# Altium schematic sheet AD7606C.SchDoc
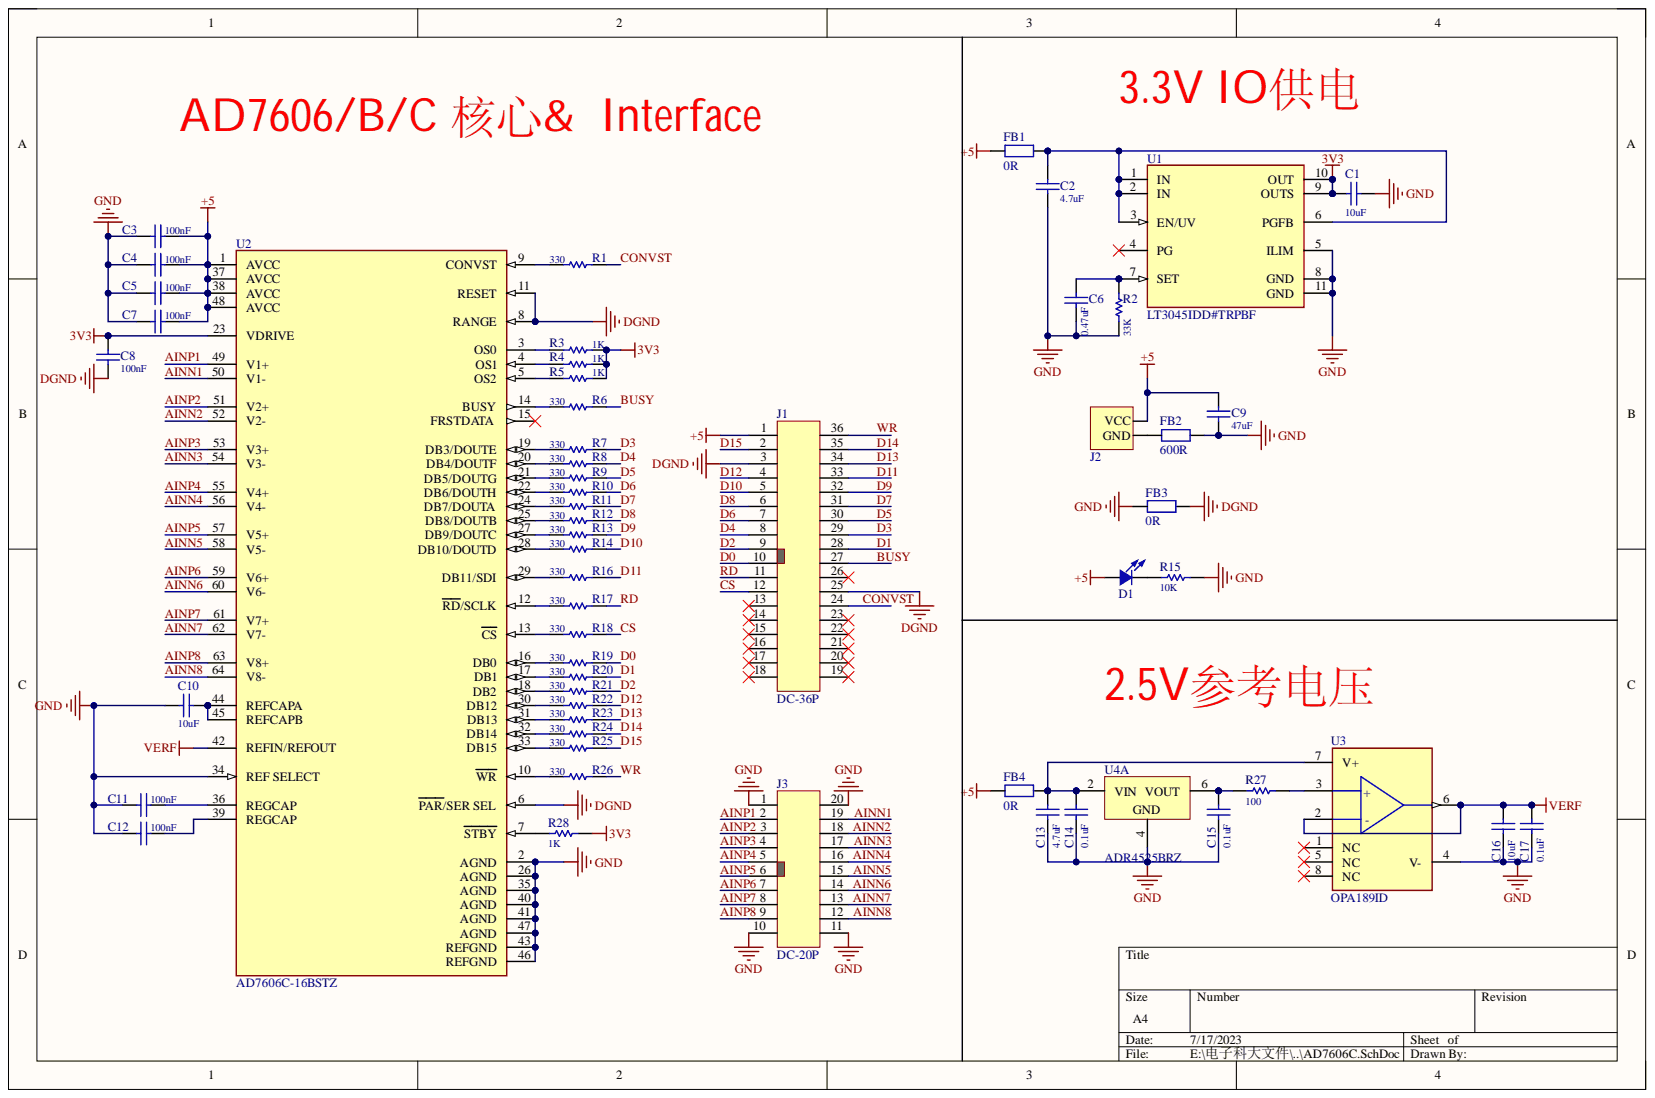
[diagram p0: AD7606C.SchDoc]

## Parts
C1  value 10uF  [C0805-L]  (hidden comment: Cap-S)
C2  value 4.7uF  [C0805-L]  (hidden comment: Cap-S)
C3  value 100nF  [C0805-L]  (hidden comment: Cap-S)
C4  value 100nF  [C0805-L]  (hidden comment: Cap-S)
C5  value 100nF  [C0805-L]  (hidden comment: Cap-S)
C6  value 0.47uF  [C0805-L]  (hidden comment: Cap-S)
C7  value 100nF  [C0805-L]  (hidden comment: Cap-S)
C8  value 100nF  [C0805-L]  (hidden comment: Cap-S)
C9  value 47uF  [C0805-L]  (hidden comment: Cap-S)
C10  value 10uF  [C0805-L]  (hidden comment: Cap-S)
C11  value 100nF  [C0805-L]  (hidden comment: Cap-S)
C12  value 100nF  [C0805-L]  (hidden comment: Cap-S)
C13  value 4.7uF  [C0805-L]  (hidden comment: Cap-S)
C14  value 0.1uF  [C0805-L]  (hidden comment: Cap-S)
C15  value 0.1uF  [C0805-L]  (hidden comment: Cap-S)
C16  value 10uF  [C0805-L]  (hidden comment: Cap-S)
C17  value 0.1uF  [C0805-L]  (hidden comment: Cap-S)
D1  LED  [Led-0805_L]  (value not drawn)
FB1  0R  [FB-0805]
FB2  600R  [FB-0805]
FB3  0R  [FB-0805]
FB4  0R  [FB-0805]
J1  DC-36P  [DC-36P]
J2  接线座-2P  [KF128-5.08-2P]  (value not drawn)
J3  DC-20P  [DC-20P]
R1  R  value 330  [R0805-L]
R2  R  value 33K  [R0805-L]
R3  R  value 1K  [R0805-L]
R4  R  value 1K  [R0805-L]
R5  R  value 1K  [R0805-L]
R6  R  value 330  [R0805-L]
R7  R  value 330  [R0805-L]
R8  R  value 330  [R0805-L]
R9  R  value 330  [R0805-L]
R10  R  value 330  [R0805-L]
R11  R  value 330  [R0805-L]
R12  R  value 330  [R0805-L]
R13  R  value 330  [R0805-L]
R14  R  value 330  [R0805-L]
R15  R  value 10K  [R0805-L]
R16  R  value 330  [R0805-L]
R17  R  value 330  [R0805-L]
R18  R  value 330  [R0805-L]
R19  R  value 330  [R0805-L]
R20  R  value 330  [R0805-L]
R21  R  value 330  [R0805-L]
R22  R  value 330  [R0805-L]
R23  R  value 330  [R0805-L]
R24  R  value 330  [R0805-L]
R25  R  value 330  [R0805-L]
R26  R  value 330  [R0805-L]
R27  R  value 100  [R0805-L]
R28  R  value 1K  [R0805-L]
U1  LT3045IDD#TRPBF  [FP-DD-10-05-08-1699-IPC_A]
U2  AD7606C-16BSTZ  [FP-ST-64-2-IPC_C]
U3  OPA189ID  [FP-D0008A-MFG]
U4  ADR4525BRZ  [ADI-R-8_N]  (part A)

## Nets
3V3: C1.2 C8.1 R3.1 R4.1 R5.1 R28.1 U1.9(OUTS) U1.10(OUT) U2.23(VDRIVE)
+5: C3.1 C4.1 C5.1 C7.1 C9.2 D1.1 FB1.1 FB4.1 J1.1 J2.1 U2.1(AVCC) U2.37(AVCC) U2.38(AVCC) U2.48(AVCC)
DGND: C8.2 FB3.2 J1.3 J1.25 U2.6(PAR/SER_SEL) U2.8(RANGE) U2.11(RESET)
GND: C1.1 C2.1 C3.2 C4.2 C5.2 C6.1 C7.2 C9.1 C10.2 C11.2 C12.2 C13.1 C14.1 C15.1 C16.1 C17.1 FB2.2 FB3.1 J3.1 J3.10 J3.11 J3.20 R2.1 R15.1 U1.5(ILIM) U1.8(GND) U1.11(GND) U2.2(AGND) U2.26(AGND) U2.34(REF_SELECT) U2.35(AGND) U2.40(AGND) U2.41(AGND) U2.43(REFGND) U2.46(REFGND) U2.47(AGND) U3.4(V-) U4.4
VERF: C16.2 C17.2 U2.42(REFIN/REFOUT) U3.2 U3.6
AINN1: J3.19 U2.50(V1-)
AINN2: J3.18 U2.52(V2-)
AINN3: J3.17 U2.54(V3-)
AINN4: J3.16 U2.56(V4-)
AINN5: J3.15 U2.58(V5-)
AINN6: J3.14 U2.60(V6-)
AINN7: J3.13 U2.62(V7-)
AINN8: J3.12 U2.64(V8-)
AINP1: J3.2 U2.49(V1+)
AINP2: J3.3 U2.51(V2+)
AINP3: J3.4 U2.53(V3+)
AINP4: J3.5 U2.55(V4+)
AINP5: J3.6 U2.57(V5+)
AINP6: J3.7 U2.59(V6+)
AINP7: J3.8 U2.61(V7+)
AINP8: J3.9 U2.63(V8+)
BUSY: J1.27 R6.1
CONVST: J1.24 R1.1
CS: J1.12 R18.1
D0: J1.10 R19.1
D1: J1.28 R20.1
D2: J1.9 R21.1
D3: J1.29 R7.1
D4: J1.8 R8.1
D5: J1.30 R9.1
D6: J1.7 R10.1
D7: J1.31 R11.1
D8: J1.6 R12.1
D9: J1.32 R13.1
D10: J1.5 R14.1
D11: J1.33 R16.1
D12: J1.4 R22.1
D13: J1.34 R23.1
D14: J1.35 R24.1
D15: J1.2 R25.1
RD: J1.11 R17.1
WR: J1.36 R26.1
NetC2_2: C2.2 FB1.2 U1.1(IN) U1.2(IN) U1.3(EN/UV) U1.6(PGFB)
NetC6_2: C6.2 R2.2 U1.7(SET)
NetC10_1: C10.1 U2.44(REFCAPA) U2.45(REFCAPB)
NetC11_1: C11.1 U2.36(REGCAP)
NetC12_1: C12.1 U2.39(REGCAP)
NetC13_2: C13.2 C14.2 FB4.2 U3.7(V+) U4.2(VIN)
NetC15_2: C15.2 R27.2 U4.6(VOUT)
NetD1_2: D1.2 R15.2
NetFB2_1: FB2.1 J2.2
NetR1_2: R1.2 U2.9(CONVST)
NetR3_2: R3.2 U2.3(OS0)
NetR4_2: R4.2 U2.4(OS1)
NetR5_2: R5.2 U2.5(OS2)
NetR6_2: R6.2 U2.14(BUSY)
NetR7_2: R7.2 U2.19(DB3/DOUTE)
NetR8_2: R8.2 U2.20(DB4/DOUTF)
NetR9_2: R9.2 U2.21(DB5/DOUTG)
NetR10_2: R10.2 U2.22(DB6/DOUTH)
NetR11_2: R11.2 U2.24(DB7/DOUTA)
NetR12_2: R12.2 U2.25(DB8/DOUTB)
NetR13_2: R13.2 U2.27(DB9/DOUTC)
NetR14_2: R14.2 U2.28(DB10/DOUTD)
NetR16_2: R16.2 U2.29(DB11/SDI)
NetR17_2: R17.2 U2.12(RD/SCLK)
NetR18_2: R18.2 U2.13(CS)
NetR19_2: R19.2 U2.16(DB0)
NetR20_2: R20.2 U2.17(DB1)
NetR21_2: R21.2 U2.18(DB2)
NetR22_2: R22.2 U2.30(DB12)
NetR23_2: R23.2 U2.31(DB13)
NetR24_2: R24.2 U2.32(DB14)
NetR25_2: R25.2 U2.33(DB15)
NetR26_2: R26.2 U2.10(WR)
NetR27_1: R27.1 U3.3
NetR28_2: R28.2 U2.7(STBY)

Not connected: J1 13 14 15 16 17 18 19 20 21 22 23 26; U1 4(PG); U2 15(FRSTDATA); U3 1(NC) 5(NC) 8(NC)

## Library data of the parts
C1-C17 = Cap-S: 贴片瓷片电容; Component Kind: Standard; Library Name: HZZ.IntLib
D1 = LED: 发光二极管; Component Kind: Standard; Library Name: HZZ.IntLib
FB1-FB4 = FB: Component Kind: Standard; Library Name: HZZ.IntLib
R1-R28 = Res: 贴片电阻; Component Kind: Standard; Library Name: HZZ.IntLib
U1 = CMP-09138-000122-1: IC REG LINEAR 0V 500MA 10DFN; Case/Package: DFN; Component Kind: Standard; Library Name: Altium Content Vault
U2 = CMP-09081-000143-1: IC ADC 16BIT SAR 64LQFP; Component Kind: Standard; Library Name: Altium Content Vault; RoHS Compliant: Yes
U3 = CMP-04895-000314-1: IC OPAMP ZERO-DRIFT 1 CIRC 8SOIC; Gain Bandwidth Product: 14MHz; Input Bias Current: 70pA; Input Offset Voltage (Vos): 0.4uV; Number of Channels: 1; Operating Supply Current: 1.3mA; Slew Rate: 20V/µs; Case/Package: SOIC; Component Kind: Standard; Library Name: Altium Content Vault; Max Operating Temperature: 125°C; Min Operating Temperature: -40°C; Mount: Surface Mount; Number of Circuits: 1; Packaging: Rail/Tube; RoHS Compliant: Yes
U4 = CMP-0784-00254-1: Ultralow Noise, High Accuracy Voltage Reference, 2.5 V Output, 3 to 15 V Supply, Industrial, 8-pin SOIC (R-8), Tube; Manufacturer: Analog Devices; Max V Supply (V): 15; Min V Supply (V): 3; Ref Out TC (ppm/C): 2; Vout: 2.5V; Code_JEDEC: MS-012-AA; Component Kind: Standard; ComponentLink1Description: Manufacturer URL; ComponentLink1URL: http://www.analog.com/en/index.html; ComponentLink2Description: Datasheet; ComponentLink2URL: http://www.analog.com/static/imported-files/data_sheets/ADR4520_4525_4530_4533_4540_4550.pdf; ComponentLink3Description: Package Specification; ComponentLink3URL: http://www.analog.com/static/imported-files/packages/PKG_PDF/SOIC_Narrow%20(R)/R_8.pdf; ComponentLink4Description: URL Link1; ComponentLink4URL: Product URL:http://www.analog.com/en/special-linear-functions/voltage-references/adr4525/products/product.html; DatasheetVersion: Rev. 0; Library Name: Altium Content Vault; Mounting Technology: Surface Mount; Operating Temp Range: -40 to 125 degC; PackageDescription: 8-Pin Standard Small Outline Package, Body 4.9 x 3.9 mm, Pitch 1.27 mm; PackageReference: R-8; PackageVersion: 060506-A, 06/2006; Packing: Tube; PartNumber: ADR4525BRZ
J1 = DC-36P: Component Kind: Standard; Library Name: HZZ.IntLib
J2 = 接线座-2P: Component Kind: Standard; Library Name: HZZ.IntLib
J3 = DC-20P: Component Kind: Standard; Library Name: HZZ.IntLib
